# Altium schematic sheet board.SchDoc
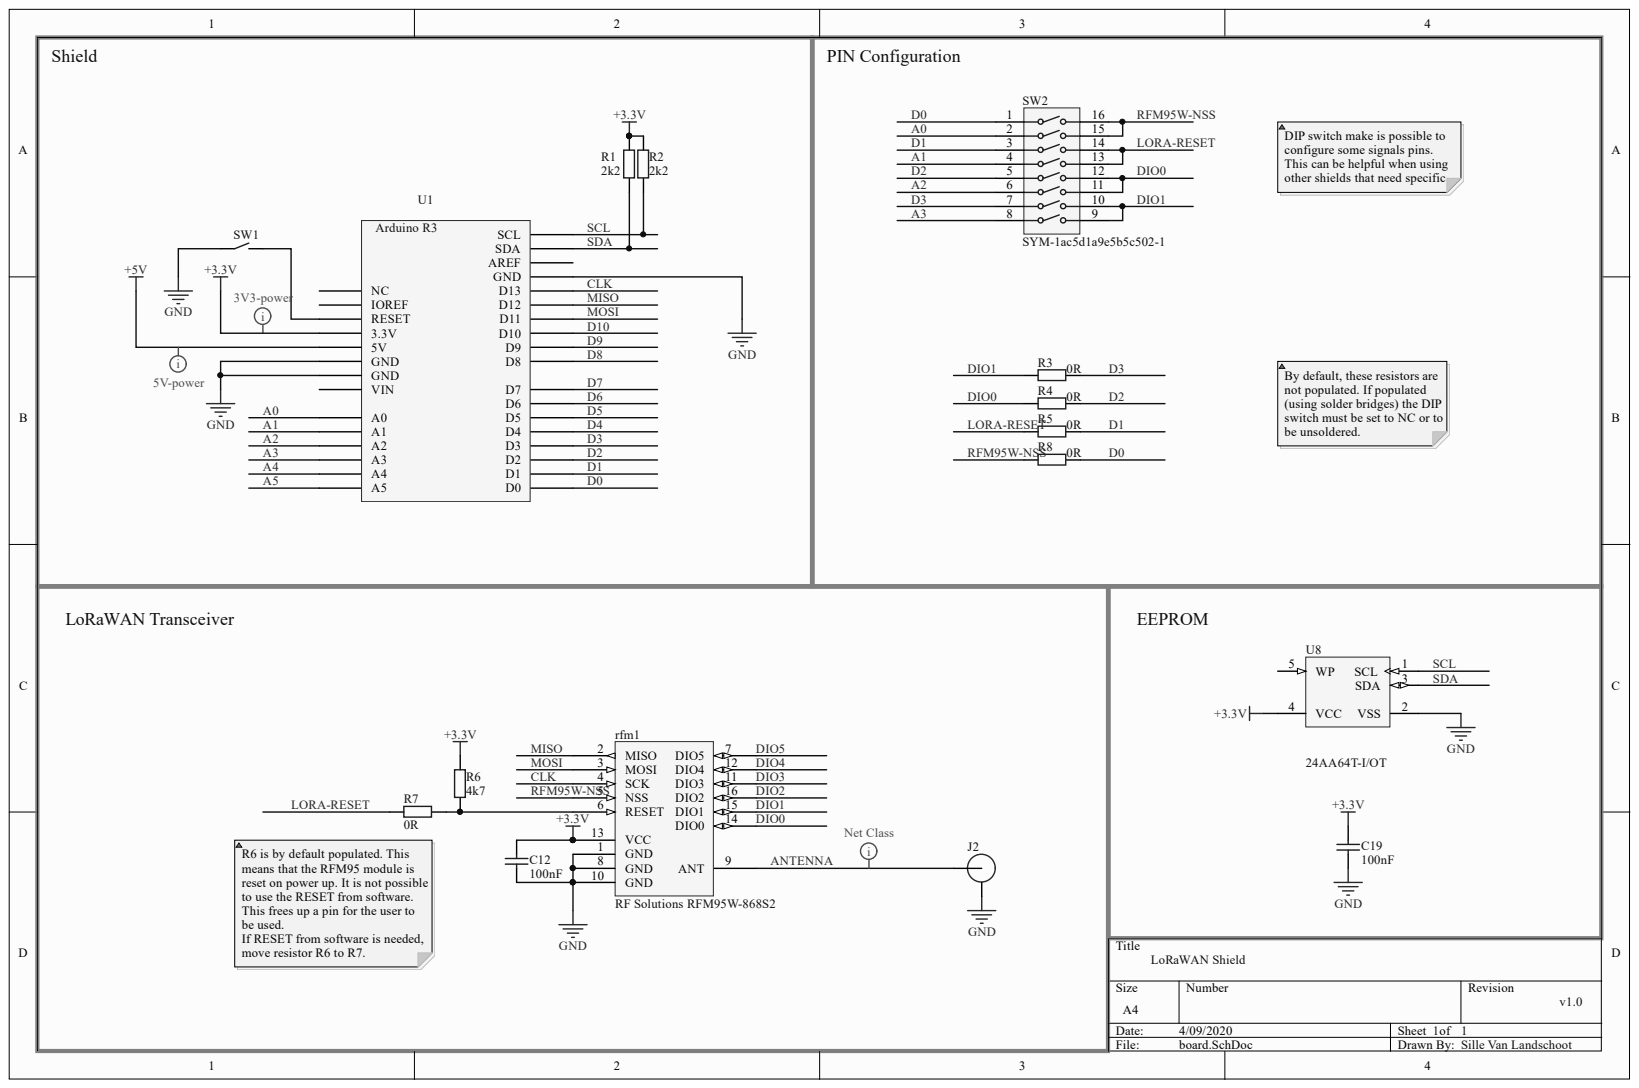
[diagram p0: board.SchDoc]

## Parts
C12  100nF  [PCB-14j6yrx10pagyq47cjuv-1]
C19  100nF  [PCB-14j6yrx10pagyq47cjuv-1]
J2  Multicomp 19-70-1-TGG  [PCB-319hzbhc3utknsftgyak-1]  (value not drawn)
R1  2k2  [PCB-5j234q72qzomlimn5nxa-1]
R2  2k2  [PCB-5j234q72qzomlimn5nxa-1]
R3  0R  [PCB-5j234q72qzomlimn5nxa-1]
R4  0R  [PCB-5j234q72qzomlimn5nxa-1]
R5  0R  [PCB-5j234q72qzomlimn5nxa-1]
R6  4k7  [PCB-1vayfl3026fycqkmw7iz-1]
R7  0R  [PCB-ft0dcd0ut8iq5myyq8j7-1]
R8  0R  [PCB-5j234q72qzomlimn5nxa-1]
rfm1  RF Solutions RFM95W-868S2  Manufacturer Part Number: RFM95W-868S2  [PCB-2a6x3qap8y64pm23m1ij-1]
SW1  Multicomp DTSM-32S-B  [PCB-ris1royc9wg8soeo1qsf-1]  (value not drawn)
SW2  SYM-1ac5d1a9e5b5c502-1  [SIP SWITCH]
U1  Adafruit Industries 85  [PCB-qf24wffkiumvw1y2m4ko-1]  (value not drawn)
U8  24AA64T-I/OT  [SOT-23-OT5_N]

## Nets
+3.3V: C12.2 C19.1 R1.2 R2.1 R6.2 rfm1.13(VCC) U1.3.3V U8.4(VCC)
+5V: U1.5V
GND: C12.1 C19.2 J2.GND rfm1.1(GND) rfm1.8(GND) rfm1.10(GND) SW1.1 U1.GND U8.2(VSS)
A0: SW2.2 U1.A0
A1: SW2.4 U1.A1
A2: SW2.6 U1.A2
A3: SW2.8 U1.A3
A4: U1.A4
A5: U1.A5
ANTENNA: J2.SIG rfm1.9(ANT)
CLK: rfm1.4(SCK) U1.D13
D0: R8.1 SW2.1 U1.D0
D1: R5.1 SW2.3 U1.D1
D2: R4.1 SW2.5 U1.D2
D3: R3.1 SW2.7 U1.D3
D4: U1.D4
D5: U1.D5
D6: U1.D6
D7: U1.D7
D8: U1.D8
D9: U1.D9
D10: U1.D10
DIO0: R4.2 rfm1.14(DIO0) SW2.11 SW2.12
DIO1: R3.2 rfm1.15(DIO1) SW2.9 SW2.10
DIO2: rfm1.16(DIO2)
DIO3: rfm1.11(DIO3)
DIO4: rfm1.12(DIO4)
DIO5: rfm1.7(DIO5)
LORA-RESET: R5.2 R7.1 SW2.13 SW2.14
MISO: rfm1.2(MISO) U1.D12
MOSI: rfm1.3(MOSI) U1.D11
RFM95W-NSS: R8.2 rfm1.5(NSS) SW2.15 SW2.16
SCL: R2.2 U1.SCL U8.1(SCL)
SDA: R1.1 U1.SDA U8.3(SDA)
NetR6_1: R6.1 R7.2 rfm1.6(RESET)
NetSW1_2: SW1.2 U1.RESET

Not connected: U1 AREF IOREF NC VIN; U8 5(WP)

## Library data of the parts
C12 C19 = CMP-cab80115e1b0157f-1: 0603 1 uF 10 V ±10 % Tol. X5R Surface Mount Multilayer Ceramic Capacitor; Capacitance Tolerance: ±10%; Lead-Free Status: Lead Free; Voltage Rating (DC): 10.0 V; Case/Package: 0603; Component Kind: Standard; Dielectric Characteristic: X5R; Library Name: CommunityVault; Mounting Style: Surface Mount; OctopartID: cab80115e1b0157f; Octopart URL: http://octopart.com/0603x105k100ct-walsin+technologies-44502638; Packaging: Cut Tape (CT); RoHS: Compliant
J2 = CMP-cdb104e8e6b51276-4: SMA Connector; Connector Type: SMA Coaxial; Impedance: 50ohm; Contact Material: Beryllium Copper; Contact Plating: Go...; Insulation Resistance: 5.00 GΩ; Number of Mating Cycles: 500; Component Kind: Standard; Library Name: CommunityVault; Manufacturer Part Number: 19-70-1-TGG; Material: Brass; Mounting Style: PCB; RoHS: Compliant
R1-R5 R8 = CMP-6e67a46e1d62b1a0-1: Surface Mount Thick Film Resistor, MCWR Series, 2.2 kohm, 100 mW, - 1%, 50 V; Manufacturer Part Number: MCWR06X2201FTL; Power Rating: 100 mW; Case/Package: 0603, 1608; Component Kind: Standard; Library Name: CommunityVault; OctopartID: 6e67a46e1d62b1a0; REACH SVHC Compliance: No SVHC; Resistance Tolerance: ±1%; RoHS: Compliant
R6 = CMP-91545b5de2944e93-1: Surface Mount Thick Film Resistor, MCWR Series, 4.7 kohm, 100 mW, - 1%, 50 V; Manufacturer Part Number: MCWR06X4701FTL; Case/Package (SI): 1608; Power Rating: 100 mW; Resistance: 4.70 kΩ; Case/Package: 0603, 1608; Component Kind: Standard; Library Name: CommunityVault; OctopartID: 91545b5de2944e93; Octopart URL: http://octopart.com/mcwr06x4701ftl-multicomp-50550438; Packaging: Tape & Reel (TR), Cut Tape (CT); REACH SVHC Compliance: No SVHC; Resistance Tolerance: ±1%; RoHS: Compliant
R7 = CMP-56f49cfd25b78933-1: Surface Mount Chip Resistor, Thick Film, MCWR Series, 0 ohm, 100 mW, 0603 [1608 Metric]; Power Rating: 100 mW; Resistance: 0.00 Ω; Voltage Rating (DC): 50.0 V; Case/Package: 0603, 1608; Component Kind: Standard; Library Name: CommunityVault; OctopartID: 56f49cfd25b78933; Octopart URL: http://octopart.com/mcwr06x000+ptl-multicomp-50550309; Packaging: Cut Tape (CT), Tape & Reel (TR)
rfm1 = CMP-d0331a1d54b00ff4-3: Module: RF; FM transceiver; FSK; 868MHz; -139dBm; 1.8÷3.7VDC; 20d; Component Kind: Standard; Library Name: CommunityVault; OctopartID: d0331a1d54b00ff4; Octopart URL: http://octopart.com/rfm95w-868s2-rf+solutions-52732389
SW1 = CMP-86404f18d99f5987-1: Tactile Switch; Series: DTS; Circuitry: SPST-NO; Contact Voltage DC Max: 12V; Contact Current DC Max: 50mA; Switch Te...; Component Kind: Standard; Library Name: CommunityVault; Octopart URL: http://octopart.com/dtsm-32s-b-multicomp-5405369; RoHS: Compliant; Size-Height: 5.00 mm; Size-Length: 6.00 mm
SW2 = CMP-74ebbdf15784ab2c-2: Switch; Slide; DIP; SPST; 8 Pos; Raised Actuator; Surface Mount; 25mA; 24VDC; Gull Wing; Manufacturer Part Number: SDA08H1SBD; Component Kind: Standard; Contacts Type: SPST; Library Name: CommunityVault; Mounting Style: Surface Mount; OctopartID: 74ebbdf15784ab2c; RoHS: Compliant
U1 = CMP-6c1fa4a6ab6db3d8-13: arduino header; Manufacturer Part Number: 85; Current Rating: 40.0 mA; Lead-Free Status: Lead Free; Supply Voltage (DC): 28.0 V; Component Kind: Standard; Library Name: CommunityVault; Mounting Style: Snap-In; OctopartID: 6c1fa4a6ab6db3d8; Octopart URL: http://octopart.com/85-adafruit+industries-28566036; RoHS: Compliant; Size-Diameter: 5.80 mm; Size-Length: 20.3 mm; Voltage Rating (DC): 28.0 V
U8 = CMP-971312-1: 64Kbit, 400kHz, 1.7V, I2C Serial EEPROM, 5-Pin SOT-23, Industrial Temperature, Tape and Reel; Access Time (Max): 3500 ns; CiivaID: 971312; Data Retention: 200; Density: 65536 Bit; Frequency: 0.1 MHz; Interface Type: Serial (I2C); Operating Supply Voltage (Max): 5.5 V; Operating Supply Voltage (Typ): 1.8/2.5/3.3/5 V; Organization: 8Kx8; Programmable: Yes; Rad Hardened: No; Supply Current: 3 mA; Ciiva URL: https://ciiva.com/part/24AA64T-I/OT-971312; Component Kind: Standard; Library Name: CommunityVault; Mounting: Surface Mount; Operating Supply Voltage (Min): 1.7 V; Operating Temperature (Max): 85C; Operating Temperature (Min): -40C; Operating Temperature Classification: Industrial; Operating Temp Range: -40C to 85C; Package Type: SOT-23; Packaging: Tape and Reel; Pin Count: 5; Write Protection: Yes
